# Altium schematic sheet VoV1366_v0.8.SchDoc(VoV1366_v0.8)
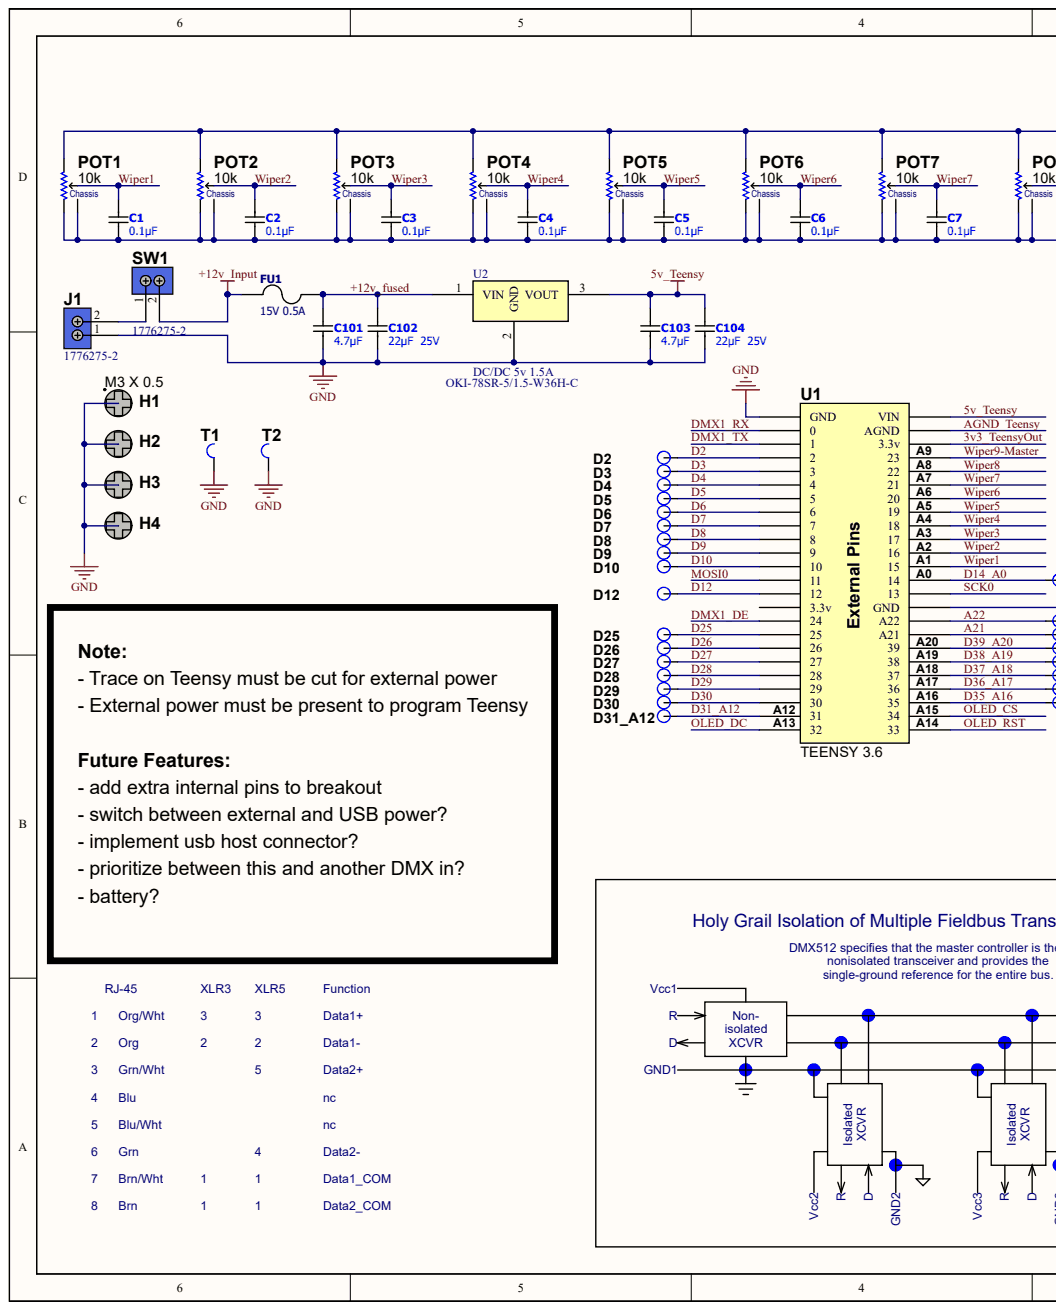
[diagram p0: VoV1366_v0.8.SchDoc, left]
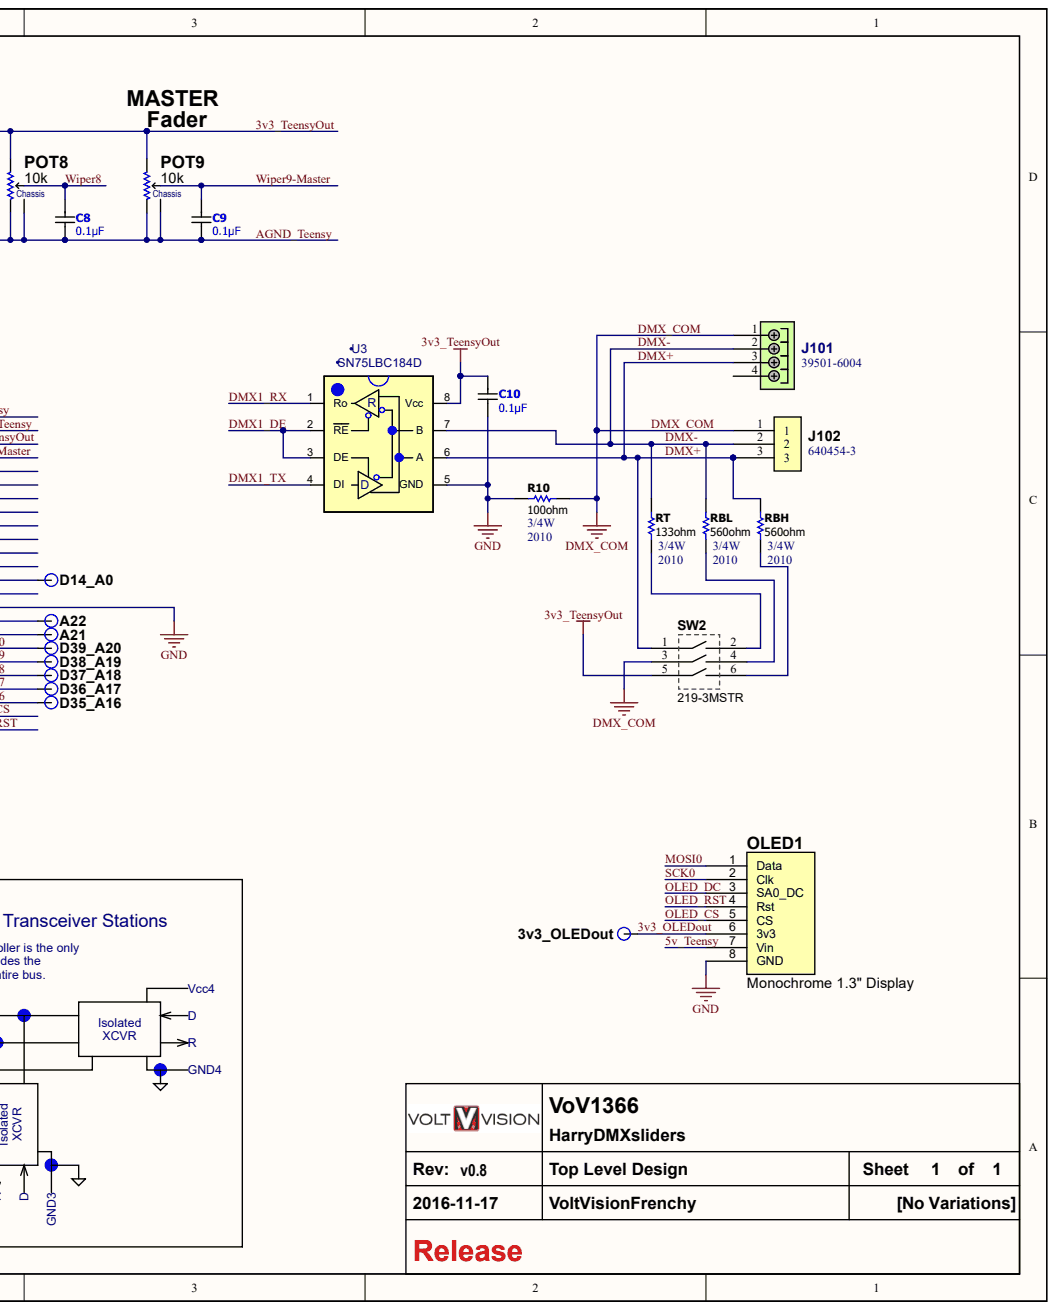
[diagram p1: VoV1366_v0.8.SchDoc, right]

## Parts
3v3_OLEDout  FLYING_WIRE_HOLE  (value not drawn)
A21  FLYING_WIRE_HOLE  (value not drawn)
A22  FLYING_WIRE_HOLE  (value not drawn)
C1  0.1µF
C2  0.1µF
C3  0.1µF
C4  0.1µF
C5  0.1µF
C6  0.1µF
C7  0.1µF
C8  0.1µF
C9  0.1µF
C10  0.1µF
C101  4.7µF
C102  value 22µF  (hidden comment: 22µF  25V)
C103  4.7µF
C104  value 22µF  (hidden comment: 22µF  25V)
D2  FLYING_WIRE_HOLE  (value not drawn)
D3  FLYING_WIRE_HOLE  (value not drawn)
D4  FLYING_WIRE_HOLE  (value not drawn)
D5  FLYING_WIRE_HOLE  (value not drawn)
D6  FLYING_WIRE_HOLE  (value not drawn)
D7  FLYING_WIRE_HOLE  (value not drawn)
D8  FLYING_WIRE_HOLE  (value not drawn)
D9  FLYING_WIRE_HOLE  (value not drawn)
D10  FLYING_WIRE_HOLE  (value not drawn)
D12  FLYING_WIRE_HOLE  (value not drawn)
D14_A0  FLYING_WIRE_HOLE  (value not drawn)
D25  FLYING_WIRE_HOLE  (value not drawn)
D26  FLYING_WIRE_HOLE  (value not drawn)
D27  FLYING_WIRE_HOLE  (value not drawn)
D28  FLYING_WIRE_HOLE  (value not drawn)
D29  FLYING_WIRE_HOLE  (value not drawn)
D30  FLYING_WIRE_HOLE  (value not drawn)
D31_A12  FLYING_WIRE_HOLE  (value not drawn)
D35_A16  FLYING_WIRE_HOLE  (value not drawn)
D36_A17  FLYING_WIRE_HOLE  (value not drawn)
D37_A18  FLYING_WIRE_HOLE  (value not drawn)
D38_A19  FLYING_WIRE_HOLE  (value not drawn)
D39_A20  FLYING_WIRE_HOLE  (value not drawn)
FU1  Voltage - Max: 15V  [1206]  (hidden comment: 15V 0.5A)
H1  M3 X 0.5
H2  M3 X 0.5  (value not drawn)
H3  M3 X 0.5  (value not drawn)
H4  M3 X 0.5  (value not drawn)
J1  1776275-2
J101  39501-6004
J102  640454-3
OLED1  Monochrome 1.3" Display
POT1  10k
POT2  10k
POT3  10k
POT4  10k
POT5  10k
POT6  10k
POT7  10k
POT8  10k
POT9  10k
R10  Power (Watts): 3/4W  Supplier Package: 2010  (hidden comment: 100ohm)
RBH  Power (Watts): 3/4W  Supplier Package: 2010  (hidden comment: 560ohm)
RBL  Power (Watts): 3/4W  Supplier Package: 2010  (hidden comment: 560ohm)
RT  Power (Watts): 3/4W  Supplier Package: 2010  (hidden comment: 133ohm)
SW1  1776275-2
SW2  219-3MSTR
T1  PC TEST POINT COMPACT SMT  (value not drawn)
T2  PC TEST POINT COMPACT SMT  (value not drawn)
U1  TEENSY 3.6
U2  DC/DC 5v 1.5A  Manufacturer Part Number: OKI-78SR-5/1.5-W36H-C  Current - Output (Max): 1.5A
U3  value SN75LBC184D  (hidden comment: SN75176B_SO8)

## Nets
+12V_INPUT: FU1.1 SW1.2
GND: C10.1 C101.2 C102.2 C103.2 C104.2 H1.1 H2.1 H3.1 H4.1 J1.1 OLED1.8(GND) R10.2 T1.1 T2.1 U1.GND U2.2 U3.5(GND)
3V3_OLEDOUT: 3v3_OLEDout.1 OLED1.6(3v3)
3V3_TEENSYOUT: C10.2 POT1.3 POT2.3 POT3.3 POT4.3 POT5.3 POT6.3 POT7.3 POT8.3 POT9.3 SW2.5 U1.3.3v_a(3.3v) U3.8(Vcc)
5V_TEENSY: C103.1 C104.1 OLED1.7(Vin) U1.VIN U2.3
+12V_FUSED: C101.1 C102.1 FU1.2 U2.1
A21: A21.1 U1.A21
A22: A22.1 U1.A22
AGND_TEENSY: C1.1 C2.1 C3.1 C4.1 C5.1 C6.1 C7.1 C8.1 C9.1 POT1.1 POT1.CHASSIS POT2.1 POT2.CHASSIS POT3.1 POT3.CHASSIS POT4.1 POT4.CHASSIS POT5.1 POT5.CHASSIS POT6.1 POT6.CHASSIS POT7.1 POT7.CHASSIS POT8.1 POT8.CHASSIS POT9.1 POT9.CHASSIS U1.AGND
D2: D2.1 U1.2
D3: D3.1 U1.3
D4: D4.1 U1.4
D5: D5.1 U1.5
D6: D6.1 U1.6
D7: D7.1 U1.7
D8: D8.1 U1.8
D9: D9.1 U1.9
D10: D10.1 U1.10
D12: D12.1 U1.12
D14_A0: D14_A0.1 U1.14
D25: D25.1 U1.25
D26: D26.1 U1.26
D27: D27.1 U1.27
D28: D28.1 U1.28
D29: D29.1 U1.29
D30: D30.1 U1.30
D31_A12: D31_A12.1 U1.31
D35_A16: D35_A16.1 U1.35
D36_A17: D36_A17.1 U1.36
D37_A18: D37_A18.1 U1.37
D38_A19: D38_A19.1 U1.38
D39_A20: D39_A20.1 U1.39
DMX1_DE: U1.24 U3.2(RE) U3.3(DE)
DMX1_RX: U1.0 U3.1(Ro)
DMX1_TX: U1.1 U3.4(DI)
DMX+: J101.3 J102.3 RBH.2 SW2.1 U3.6(A)
DMX-: J101.2 J102.2 RBL.2 RT.2 U3.7(B)
DMX_COM: J101.1 J102.1 R10.1 SW2.3
MOSI0: OLED1.1(Data) U1.11
OLED_CS: OLED1.5(CS) U1.34
OLED_DC: OLED1.3(SA0_DC) U1.32
OLED_RST: OLED1.4(Rst) U1.33
SCK0: OLED1.2(Clk) U1.13
WIPER1: C1.2 POT1.2 U1.15
WIPER2: C2.2 POT2.2 U1.16
WIPER3: C3.2 POT3.2 U1.17
WIPER4: C4.2 POT4.2 U1.18
WIPER5: C5.2 POT5.2 U1.19
WIPER6: C6.2 POT6.2 U1.20
WIPER7: C7.2 POT7.2 U1.21
WIPER8: C8.2 POT8.2 U1.22
WIPER9-MASTER: C9.2 POT9.2 U1.23
NetJ1_2: J1.2 SW1.1
NetRBH_1: RBH.1 SW2.6
NetRBL_1: RBL.1 SW2.4
NetRT_1: RT.1 SW2.2

Not connected: J101 4; U1 3.3v_b(3.3v)

## Library data of the parts
C1-C10 = (no symbol name): Manufacturer Part Number: GRM21BR71H104KA01L; Manufacturer: Murata Electronics North America; Temperature Coefficient: X7R; Voltage - Rated: 50V; Tolerance: ±10%; Value_Voltage: 0.1µF  50V; Value: 0.1µF; ComponentLink1Description: \\altium.sil.arl.psu.edu\Libraries\datasheets\Murata Electronics North America\c02e.pdf; ComponentLink1URL: http://search.murata.co.jp/Ceramy/image/img/A01X/G101/ENG/GRM1555C1HR60WA01-01.pdf; ComponentLink2Description: External Datasheet; ComponentLink2URL: http://www.murata.com/products/catalog/pdf/c02e.pdf; Stocked: 0; Supplier 1: Digi-Key; Supplier Part Number 1: 490-1666-1-ND; Category: Capacitors; Sort Value: 100000; Sort Value Package: 0805_100000; Pricing: 1 = 0.1  ;  10 = 0.046  ;  50 = 0.0248  ;  100 = 0.0209  ;  250 = 0.01712  ;  500 = 0.01464  ;  1000 = 0.0114 (USD); Sales Status: Active; Capacitance: 0.1µF; Mounting Type: Surface Mount- MLCC; Operating Temperature: -55°C ~ 125°C; Applications: General Purpose; Ratings: -; Package: 0805 (2012 Metric); Size / Dimension: 0.079" L x 0.049" W (2.00mm x 1.25mm); Height - Seated (Max): -; Thickness (Max): 0.053" (1.35mm); Lead Spacing: -; Features: -; Packaging: Cut Tape (CT); Lead Style: -; Supplier Package: 0805; Thickness: 135
C101 C103 = (no symbol name): Manufacturer Part Number: GRM31CR71H475KA12L; Manufacturer: Murata Electronics North America; Temperature Coefficient: X7R; Voltage - Rated: 50V; Tolerance: ±10%; Value_Voltage: 4.7µF  50V; Value: 4.7µF; ComponentLink1Description: \\altium.sil.arl.psu.edu\Libraries\datasheets\Murata Electronics North America\c02e.pdf; ComponentLink1URL: http://search.murata.co.jp/Ceramy/image/img/A01X/G101/ENG/GRM1555C1HR60WA01-01.pdf; ComponentLink2Description: External Datasheet; ComponentLink2URL: http://www.murata.com/products/catalog/pdf/c02e.pdf; Stocked: 0; Supplier 1: Digi-Key; Supplier Part Number 1: 490-6521-1-ND; Category: Capacitors; Sort Value: 4700000; Sort Value Package: 1206_4700000; Pricing: 1 = 0.9  ;  10 = 0.762  ;  50 = 0.5608  ;  100 = 0.4458  ;  250 = 0.37388  ;  500 = 0.33794  ;  1000 = 0.30917 (USD); Sales Status: Active; Capacitance: 4.7µF; Mounting Type: Surface Mount- MLCC; Operating Temperature: -55°C ~ 125°C; Applications: General Purpose; Ratings: -; Package: 1206 (3216 Metric); Size / Dimension: 0.126" L x 0.063" W (3.20mm x 1.60mm); Height - Seated (Max): -; Thickness (Max): 0.071" (1.80mm); Lead Spacing: -; Features: -; Packaging: Cut Tape (CT); Lead Style: -; Supplier Package: 1206; Thickness: 180
C102 C104 = (no symbol name): Manufacturer Part Number: GRM32ER71E226KE15L; Manufacturer: Murata Electronics North America; Temperature Coefficient: X7R; Tolerance: ±10%; ComponentLink1Description: \\altium.sil.arl.psu.edu\Libraries\datasheets\Murata Electronics North America\GRM32ER71E226KE15%23.pdf; ComponentLink1URL: http://search.murata.co.jp/Ceramy/image/img/A01X/G101/ENG/GRM1555C1HR60WA01-01.pdf; ComponentLink2Description: External Datasheet; ComponentLink2URL: http://psearch.murata.com/capacitor/product/GRM32ER71E226KE15%23.pdf; Stocked: 0; Supplier 1: Digi-Key; Supplier Part Number 1: 490-5313-1-ND; Category: Capacitors; Sort Value: 22000000; Sort Value Package: 1210_22000000; Pricing: 1 = 1.33  ;  10 = 1.113  ;  50 = 0.9002  ;  100 = 0.7107  ;  250 = 0.59224  ;  500 = 0.53302 (USD); Sales Status: Active; Capacitance: 22µF; Voltage - Rated: 25V; Mounting Type: Surface Mount- MLCC; Operating Temperature: -55°C ~ 125°C; Applications: General Purpose; Ratings: -; Package: 1210 (3225 Metric); Size / Dimension: 0.126" L x 0.098" W (3.20mm x 2.50mm); Height - Seated (Max): -; Thickness (Max): 0.106" (2.70mm); Lead Spacing: -; Features: -; Packaging: Cut Tape (CT); Lead Style: -; Supplier Package: 1210; Thickness: 270; Value_Voltage: 22µF  25V
FU1 = (no symbol name): Manufacturer Part Number: 1206L050/15YR; Manufacturer: Littelfuse Inc; CategorySort: 850; Current - Hold (Ih) (Max): 500mA; Current - Trip (It): 1A; Current - Max: 100A; R Min/Max: 0.150 ~ 0.750 Ohm; Time to Trip: 0.1s; Current Trip: 0.5; Value: 15V 0.5A; Sort Value: *; Category: Circuit Protection; ComponentLink1Description: Internal Datasheet; ComponentLink1URL: http://www.littelfuse.com/data/en/Data_Sheets/Littelfuse_PTC_1206L.pdf; ComponentLink2Description: External Datasheet; ComponentLink2URL: http://www.littelfuse.com/data/en/Data_Sheets/Littelfuse_PTC_1206L.pdf; Stocked: 0; Supplier 1: Digi-Key; Supplier Part Number 1: F3733CT-ND; SortValue: 15_0.5; Pricing: 1 = 0.48  ;  10 = 0.452  ;  25 = 0.4164  ;  50 = 0.3808  ;  100 = 0.3165  ;  250 = 0.28772  ;  500 = 0.24386  ;  1000 = 0.21509 (USD); Sales Status: Active; Package: 1206 (3216 Metric)- Concave- Long Side Terminals; Packaging: Cut Tape (CT)
J1 SW1 = (no symbol name): Manufacturer: TE Connectivity; Number of Levels: 1; Positions Per Level: 2; Pitch: 0.138" (3.50mm); Mating Orientation: Horizontal with Board; Current: 7A; Voltage: 250V; Wire Gauge: 18-26 AWG; Wire Termination: Screw - Leaf Spring, Wire Guard; Clamp Material - Plating: Steel, Stainless; Screw Material - Plating: Steel, Galvanized - Chromate Plated; Torque - Screw: 0.28 Nm (2.5 Lb-In); Screw Thread: M2.6; Supplier 1: Digi-Key; Supplier Part Number 1: A98036-ND; Manufacturer Part Number: 1776275-2; RoHS: RoHS Compliant; Category: Connectors, Interconnects; Stock 1: 46916; Pricing 1: 1=0.44, 10=0.417, 25=0.3572, 50=0.3036, 100=0.2917, 250=0.26196, 500=0.25004, 1000=0.20837, 2500=0.19051 (USD); Mounting Type: Through Hole; Features: Interlocking (Side); Color: Blue; Packaging: Bulk; Operating Temperature: -55°C ~ 105°C; Housing Material: Polyamide (PA66), Nylon 6/6; Contact Material - Plating: Brass - Copper Tin Plated; ComponentLink1URL: http://documents.tycoelectronics.com/commerce/DocumentDelivery/DDEController?Action=srchrtrv&DocNm=1776275&DocType=CD&DocLang=EN; ComponentLink1Description: http://documents.tycoelectronics.com/commerce/DocumentDelivery/DDEController?Action=srchrtrv&DocNm=1776275&DocType=CD&DocLang=EN; Value: 1776275-2
J101 = (no symbol name): Manufacturer: Molex; Current Rating: 8 A; Mounting Angle: Vertical; Number of Positions: 4; Voltage Rating: 300 V; Pitch: 3.5 mm; Supplier 1: Mouser; Supplier Part Number 1: 538-39501-6004; RoHS: RoHS Compliant; Category: Pluggable Terminal Blocks; Stock 1: 112; Pricing 1: 1=1.41, 25=1.33, 50=1.21, 100=1.09, 200=1.01, 500=0.927 (AUD); Product: Headers; Series: 39501; Termination Style: Through Hole; Brand: Molex; Product Category: Eurostyle Pluggable PCB Headers; Standard Pack Qty: 960; Tradename: Eurostyle; RoHS - Mouser: Y; Minimum Order Quantity: 1; Reeling: False; ComponentLink1URL: http://www.mouser.com/ds/2/276/0395016004_TERMINAL_BLOCKS-163861.pdf; ComponentLink1Description: http://www.mouser.com/ds/2/276/0395016004_TERMINAL_BLOCKS-163861.pdf; Manufacturer Part Number: 39501-6004
J102 = (no symbol name): Manufacturer: TE Connectivity; Connector Type: Header, Unshrouded; Number of Positions: 3; Number of Positions Loaded: All; Pitch: 0.100" (2.54mm); Supplier 1: Digi-Key; Supplier Part Number 1: A19430-ND; Manufacturer Part Number: 640454-3; RoHS: RoHS Compliant; Category: Connectors, Interconnects; Stock 1: 29104; Pricing 1: 1=0.17, 10=0.162, 25=0.1204, 50=0.1032, 100=0.0997, 250=0.08592, 500=0.08248, 1000=0.07218, 2500=0.0653 (USD); Contact Type: Male Pin; Number of Rows: 1; Row Spacing: -; Contact Mating Length: 0.295" (7.49mm); Mounting Type: Through Hole; Termination: Solder; Fastening Type: -; Features: Polarizing Key; Contact Finish: Tin; Contact Finish Thickness: -; Color: Natural; Packaging: Bulk; ComponentLink1URL: http://documents.tycoelectronics.com/commerce/DocumentDelivery/DDEController?Action=srchrtrv&DocNm=640454&DocType=CD&DocLang=EN; ComponentLink1Description: http://documents.tycoelectronics.com/commerce/DocumentDelivery/DDEController?Action=srchrtrv&DocNm=640454&DocType=CD&DocLang=EN; Value: 640454-3
OLED1 = (no symbol name): Manufacturer: ADAFRUIT INDUSTRIES; Manufacturer Part Number: 938; Value: Monochrome 1.3" Display; Supplier 1: Newark; Supplier Part Number 1: 53W6388; Stock 1: 0; Pricing 1: 1=24.5, 10=22.05, 100=19.6 (USD)
POT1-POT9 = (no symbol name): Manufacturer: ChineseSparkfun; Manufacturer Part Number: C2031N-1A2-B10K-GP; Resistance: 10 kOhms; Tolerance: 20 %; Number of Turns: 1; Shaft Diameter: 6 mm; Shaft Length: 20 mm; Shaft Type: Flat; Value: 10k; Supplier 1: Mouser; Supplier Part Number 1: 688-RK09L114001T; RoHS: RoHS Compliant; Category: Potentiometers; Stock 1: 4873; Pricing 1: 1=1.44, 25=1.32, 50=1.20, 100=0.959, 500=0.828, 1000=0.709, 2500=0.617 (AUD); Operating Temperature Range: ?; Packaging: Tray; Power Rating: ?; Product: Potentiometer; Series: RK09L; Termination Style: Pin; Type: Snap In; Mounting Style: Through Hole; Product Category: Potentiometers; Taper: Linear; RoHS - Mouser: Y; Minimum Order Quantity: 1; Reeling: False; ComponentLink1URL: https://www.sparkfun.com/products/11620; ComponentLink1Description: https://www.sparkfun.com/products/11620
R10 RBH RBL RT = (no symbol name): Manufacturer Part Number: ERJ-12ZYJ101U; Manufacturer: Panasonic Electronic Components; Package Power: 2010  (0.75W); Resistance (Ohms): 100; Temperature Coefficient: ±200ppm/°C; Tolerance: ±5%; Limiting Element Voltage: 200; Maximum Overload Voltage: 500; Maximum Working Voltage: 8.7V; ComponentLink1Description: Internal Datasheet; ComponentLink1URL: \\altium.sil.arl.psu.edu\Libraries\datasheets\Panasonic Electronic Components\AOA0000CE1.pdf; ComponentLink2Description: External Datasheet; ComponentLink2URL: http://industrial.panasonic.com/www-data/pdf/AOA0000/AOA0000CE1.pdf; Stocked: 0; Supplier 1: Digi-Key; Supplier Part Number 1: P100WCT-ND; Category: Resistors; Composition: Thick Film; Features: -; Package: 2010 (5025 Metric); Size / Dimension: 0.197" L x 0.098" W (5.00mm x 2.50mm); Height: 0.028" (0.70mm); Number of Terminations: 2; Packaging: Cut Tape (CT); Sort Value: 100; Sort Value Package: 2010_100
  R10: Value: 100ohm
  RBH RBL: Value: 560ohm
  RT: Value: 133ohm
SW2 = (no symbol name): Manufacturer: CTS Electrocomponents; Circuit: SPST; Number of Positions: 3; Current Rating: 100mA; Voltage Rating: 20VDC; Actuator Type: Slide (Standard); Actuator Level: Raised; Pitch: 0.100" (2.54mm), Full; Washable: Yes; Electrical Life: 2,000 Cycles; Value: 219-3MSTR; Supplier 1: Digi-Key; Supplier Part Number 1: CT3094CT-ND; Manufacturer Part Number: 219-3MSTR; RoHS: RoHS Compliant; Category: Switches; Stock 1: 1116; Pricing 1: 1=0.83, 10=0.816, 100=0.69, 500=0.59594 (USD); Packaging: Cut Tape (CT); Contact Material: Beryllium Copper; Contact Finish: Gold; Height Above Board: 0.170" (4.33mm); Mounting Type: Surface Mount; Termination Style: Gull Wing; Features: Epoxy Sealed Terminals, Tape Seal; Body Material: -; Length: 0.358" (9.09mm); Operating Temperature: -55°C ~ 85°C; Mechanical Life: -; ComponentLink1URL: http://www.ctscorp.com/wp-content/uploads/2015/11/219.pdf; ComponentLink1Description: http://www.ctscorp.com/wp-content/uploads/2015/11/219.pdf
T1 T2 = (no symbol name): Manufacturer: Keystone Electronics; Manufacturer Part Number: 5016; Type: PC Test Point, Compact; Material: Phosphor Bronze; Value: PC TEST POINT COMPACT SMT; Supplier 1: Digi-Key; RoHS: RoHS Compliant; Category: Test Equipment; Stock 1: 454070; Pricing 1: 50=0.3076, 250=0.26372, 500=0.21976 (USD); Terminal: 0.015" (0.38mm) Phosphor Bronze, Silver Plate; Mounting Type: Surface Mount; Color: -; Packaging: Cut Tape (CT); ComponentLink1URL: http://www.keyelco.com/pdfs/M55p51.pdf; ComponentLink1Description: http://www.keyelco.com/pdfs/M55p51.pdf; Supplier Part Number 1: 5016KCT-ND
U2 = (no symbol name): Manufacturer: Murata Power Solutions Inc.; Power (Watts) - Manufacture Series: 8W; Number of Outputs: 1; Voltage - Input (Min): 7V; Voltage - Input (Max): 36V; Efficiency: 90.5%; Supplier 1: Digi-Key; RoHS: RoHS Compliant; Category: Power Supplies - Board Mount; Stock 1: 10629; Pricing 1: 1=4.31, 5=4.254, 10=4.228, 25=4.1736, 50=4.038, 100=3.7669, 250=3.60432 (USD); Packaging: Tray; Part Status: Active; Type: Linear Regulator Replacement; Voltage - Output 1: 5V; Voltage - Output 2: -; Voltage - Output 3: -; Voltage - Isolation: -; Applications: ITE (Commercial); Features: SCP; Mounting Type: Through Hole; Package / Case: 3-SIP Module; Size / Dimension: 0.41" L x 0.30" W x 0.65" H (10.4mm x 7.6mm x 16.5mm); Operating Temperature: -40°C ~ 85°C; Power (Watts) - Max: 8W; ComponentLink1URL: http://power.murata.com/data/power/oki-78sr.pdf; ComponentLink1Description: http://power.murata.com/data/power/oki-78sr.pdf; Supplier Part Number 1: 811-2692-ND
3v3_OLEDout A21 A22 D2-D10 D12 D14_A0 D25-D30 D31_A12 D35_A16 D36_A17 D37_A18 D38_A19 D39_A20 H1-H4 U1 U3 = (no symbol name)
  3v3_OLEDout A21 A22 D2-D10 D12 D14_A0 D25-D30 D31_A12 D35_A16 D36_A17 D37_A18 D38_A19 D39_A20: Source: *; Source Part Number: *; Manufacturer Part Number: *; ROHS Certificate: *; Value: *
  H1-H4: Value: M3 X 0.5; Manufacturer: *; Manufacturer Part Number: *; Supplier 1: *; Supplier Part Number 1: *
